# Altium schematic sheet main.SchDoc(main)
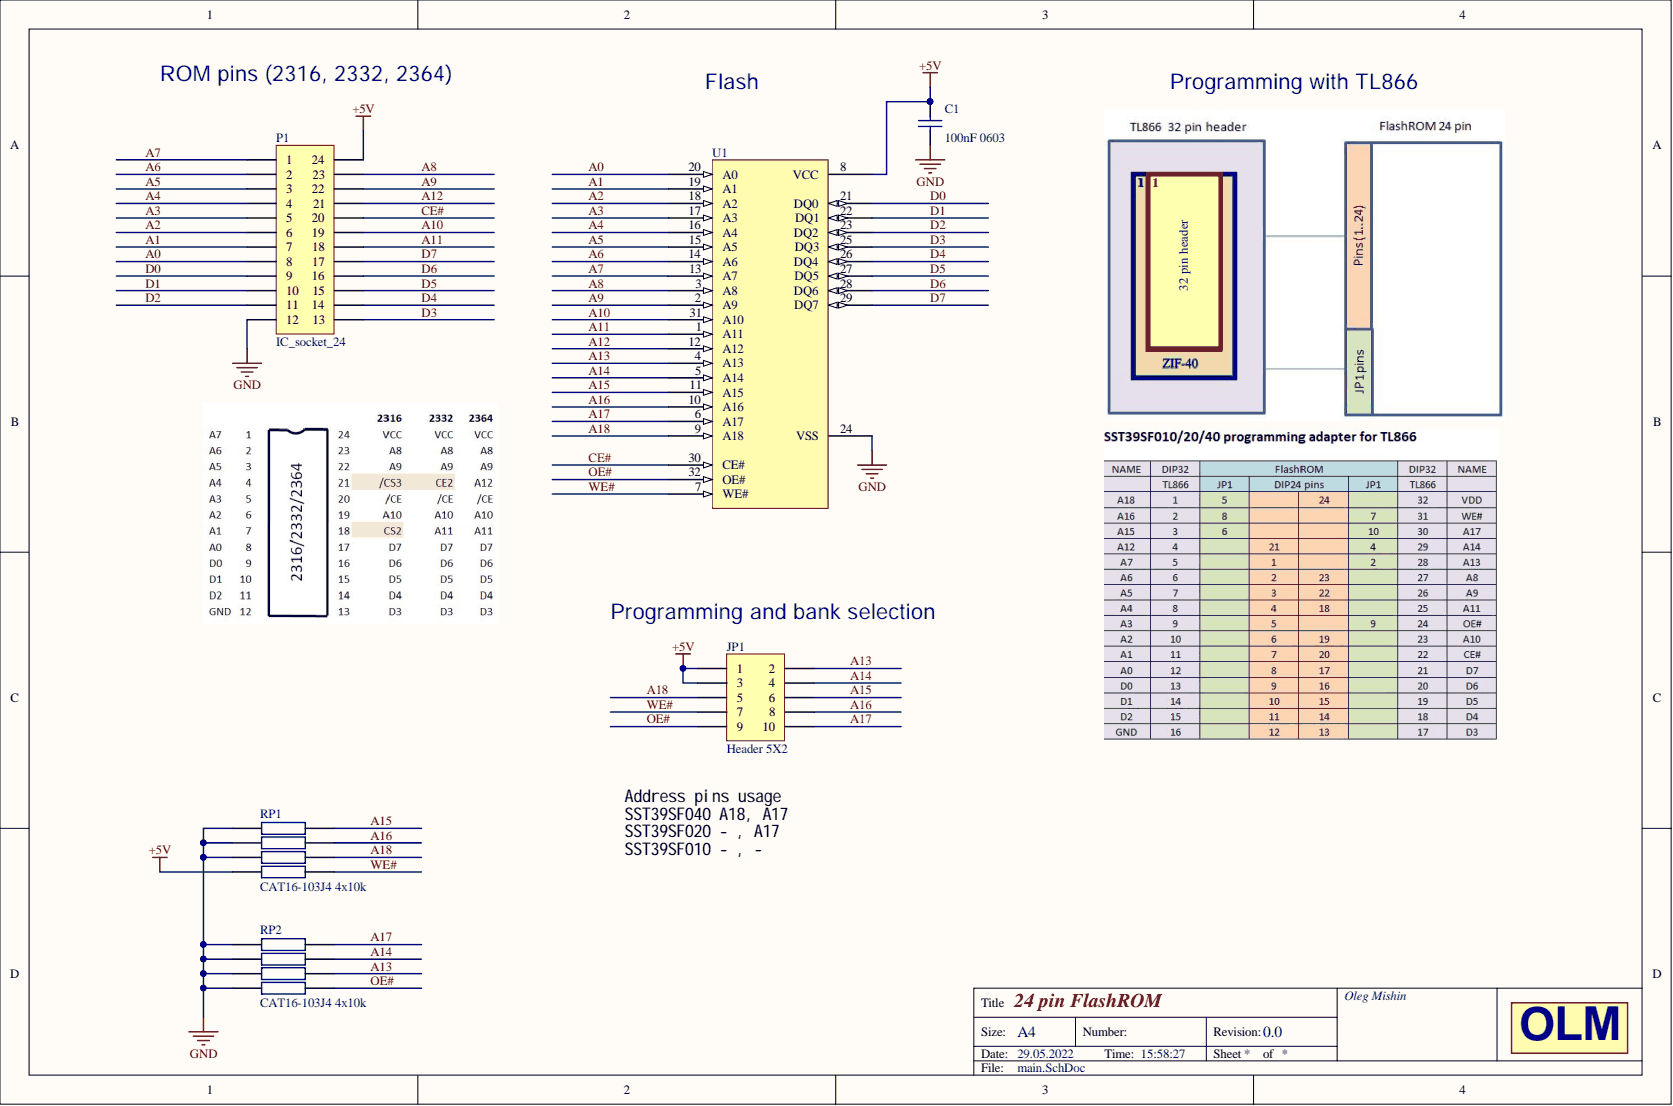
[diagram p0: main.SchDoc]

## Parts
C1  value 100nF 0603  [SM/C_0603]  (hidden comment: Cap)
JP1  Header 5X2  [PLD-10 2mm]
P1  IC_socket_24  [Header DIP24]
RP1  value CAT16-103J4 4х10k  [RES_PACK_CAT16]  (hidden comment: Res Pack)
RP2  value CAT16-103J4 4х10k  [RES_PACK_CAT16]  (hidden comment: Res Pack)
U1  SST39SF040A  [TSOP32_WH_SST_MCH]

## Nets
+5V: C1.2 JP1.1 JP1.3 P1.24 RP1.5 U1.8(VCC)
GND: C1.1 P1.12 RP1.6 RP1.7 RP1.8 RP2.5 RP2.6 RP2.7 RP2.8 U1.24(VSS)
A0: P1.8 U1.20(A0)
A1: P1.7 U1.19(A1)
A2: P1.6 U1.18(A2)
A3: P1.5 U1.17(A3)
A4: P1.4 U1.16(A4)
A5: P1.3 U1.15(A5)
A6: P1.2 U1.14(A6)
A7: P1.1 U1.13(A7)
A8: P1.23 U1.3(A8)
A9: P1.22 U1.2(A9)
A10: P1.19 U1.31(A10)
A11: P1.18 U1.1(A11)
A12: P1.21 U1.12(A12)
A13: JP1.2 RP2.3 U1.4(A13)
A14: JP1.4 RP2.2 U1.5(A14)
A15: JP1.6 RP1.1 U1.11(A15)
A16: JP1.8 RP1.2 U1.10(A16)
A17: JP1.10 RP2.1 U1.6(A17)
A18: JP1.5 RP1.3 U1.9(A18)
CE#: P1.20 U1.30(CE#)
D0: P1.9 U1.21(DQ0)
D1: P1.10 U1.22(DQ1)
D2: P1.11 U1.23(DQ2)
D3: P1.13 U1.25(DQ3)
D4: P1.14 U1.26(DQ4)
D5: P1.15 U1.27(DQ5)
D6: P1.16 U1.28(DQ6)
D7: P1.17 U1.29(DQ7)
OE#: JP1.9 RP2.4 U1.32(OE#)
WE#: JP1.7 RP1.4 U1.7(WE#)

## Library data of the parts
C1 = Cap ceramic: Ceramic capacitor SMD; Component Kind: Standard; Library Name: New.SCHLIB
JP1 = Header 5X2: Header, 5-Pin, Dual row; Component Kind: Standard; LatestRevisionDate: 17-Jul-2002; LatestRevisionNote: Re-released for DXP Platform.; Library Name: Miscellaneous Connectors.IntLib; Signal Integrity: Connector; Publisher: Altium Limited
P1 = IC_socket_24: IC socket; Component Kind: Standard; LatestRevisionDate: 17-Jul-2002; LatestRevisionNote: Re-released for DXP Platform.; Library Name: New_home.SCHLIB; Signal Integrity: Connector; Publisher: Altium Limited
RP1 RP2 = RES_PACK_4: Component Kind: Standard; Library Name: New.SCHLIB
U1 = SST39SF040A: 4Mb FLASH MEMORY; Manufacturer: Micron Technology Inc; CategoryI: Integrated Circuits (ICs); CategoryII: NOR FLASH MEMORY; DesignedBy: Circuitry Parts; SchematicRev: A; CircuitryPartsPN: SST39SF040A; Component Kind: Standard; DesignedOn: 7/18/2005 3:14:54 PM; Library Name: NOR_FLASH.schlib; ManufacturerPN: SST39SF040A; MfgURL: http://www.micron.com/; PackageName: TSOP32
